# Altium schematic sheet [09] - USB HOST and SD CARD.SchDoc("[09] - USB HOST and SD CARD")
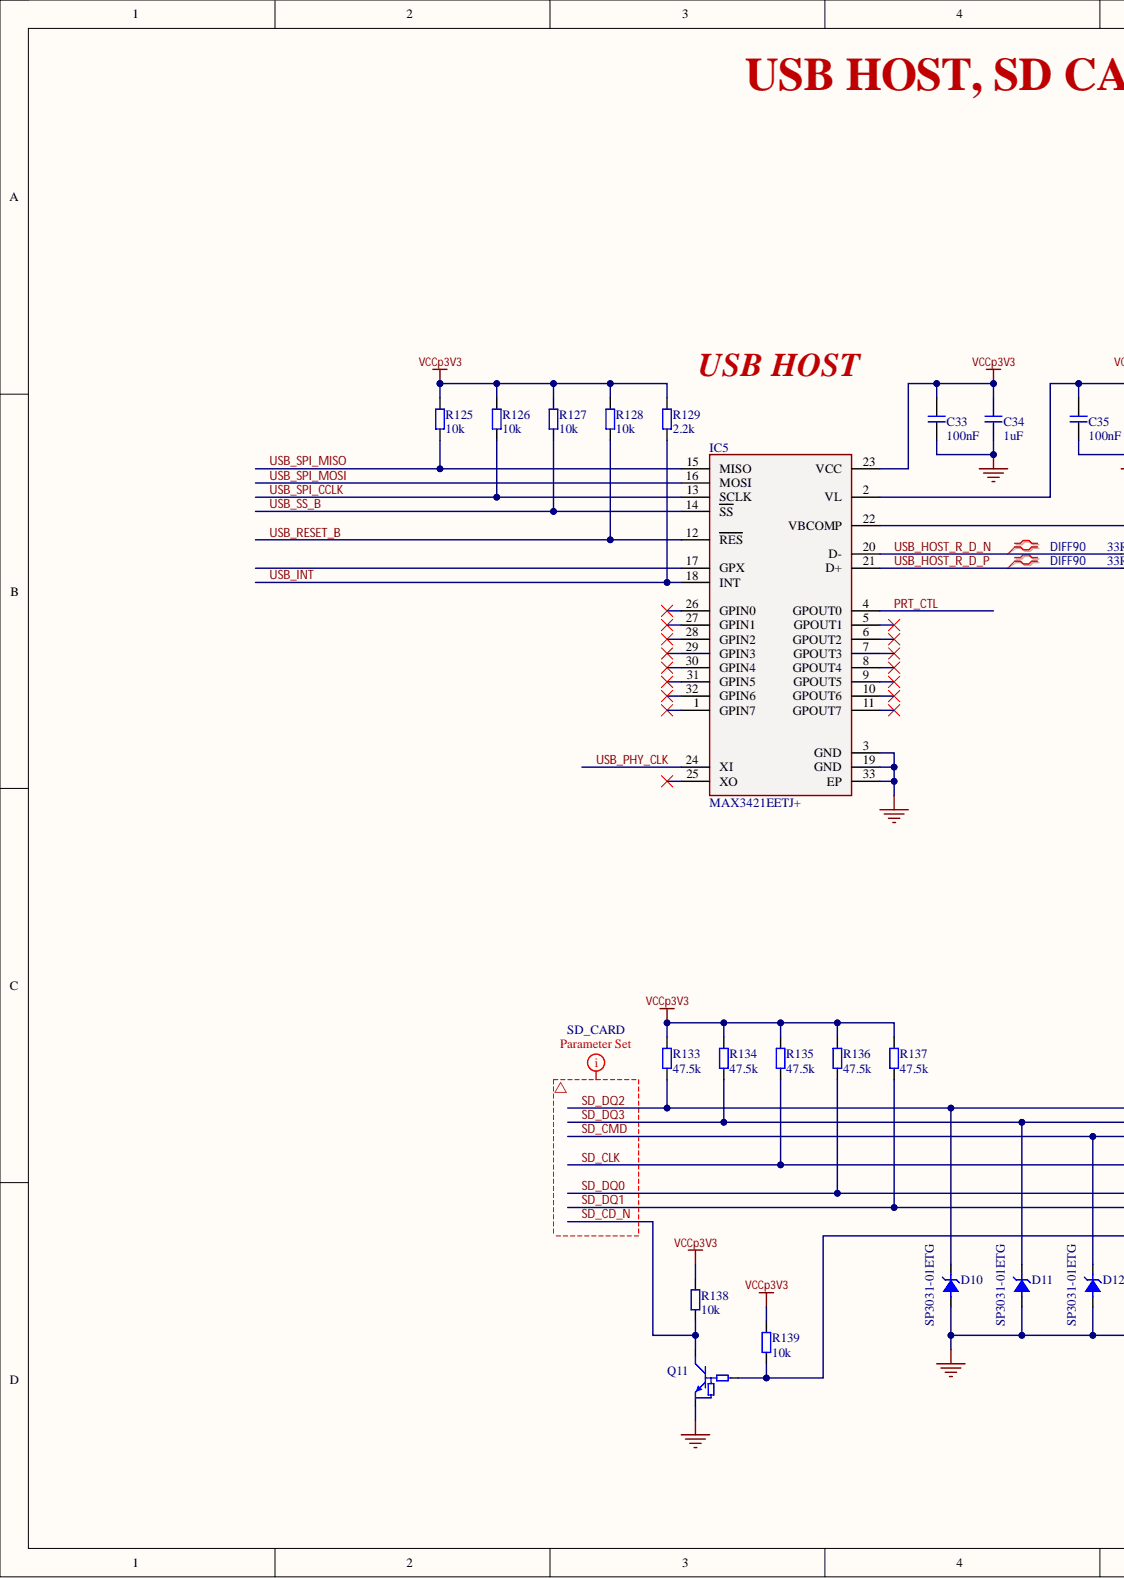
[diagram p0: [09] - USB HOST and SD CARD.SchDoc, left]
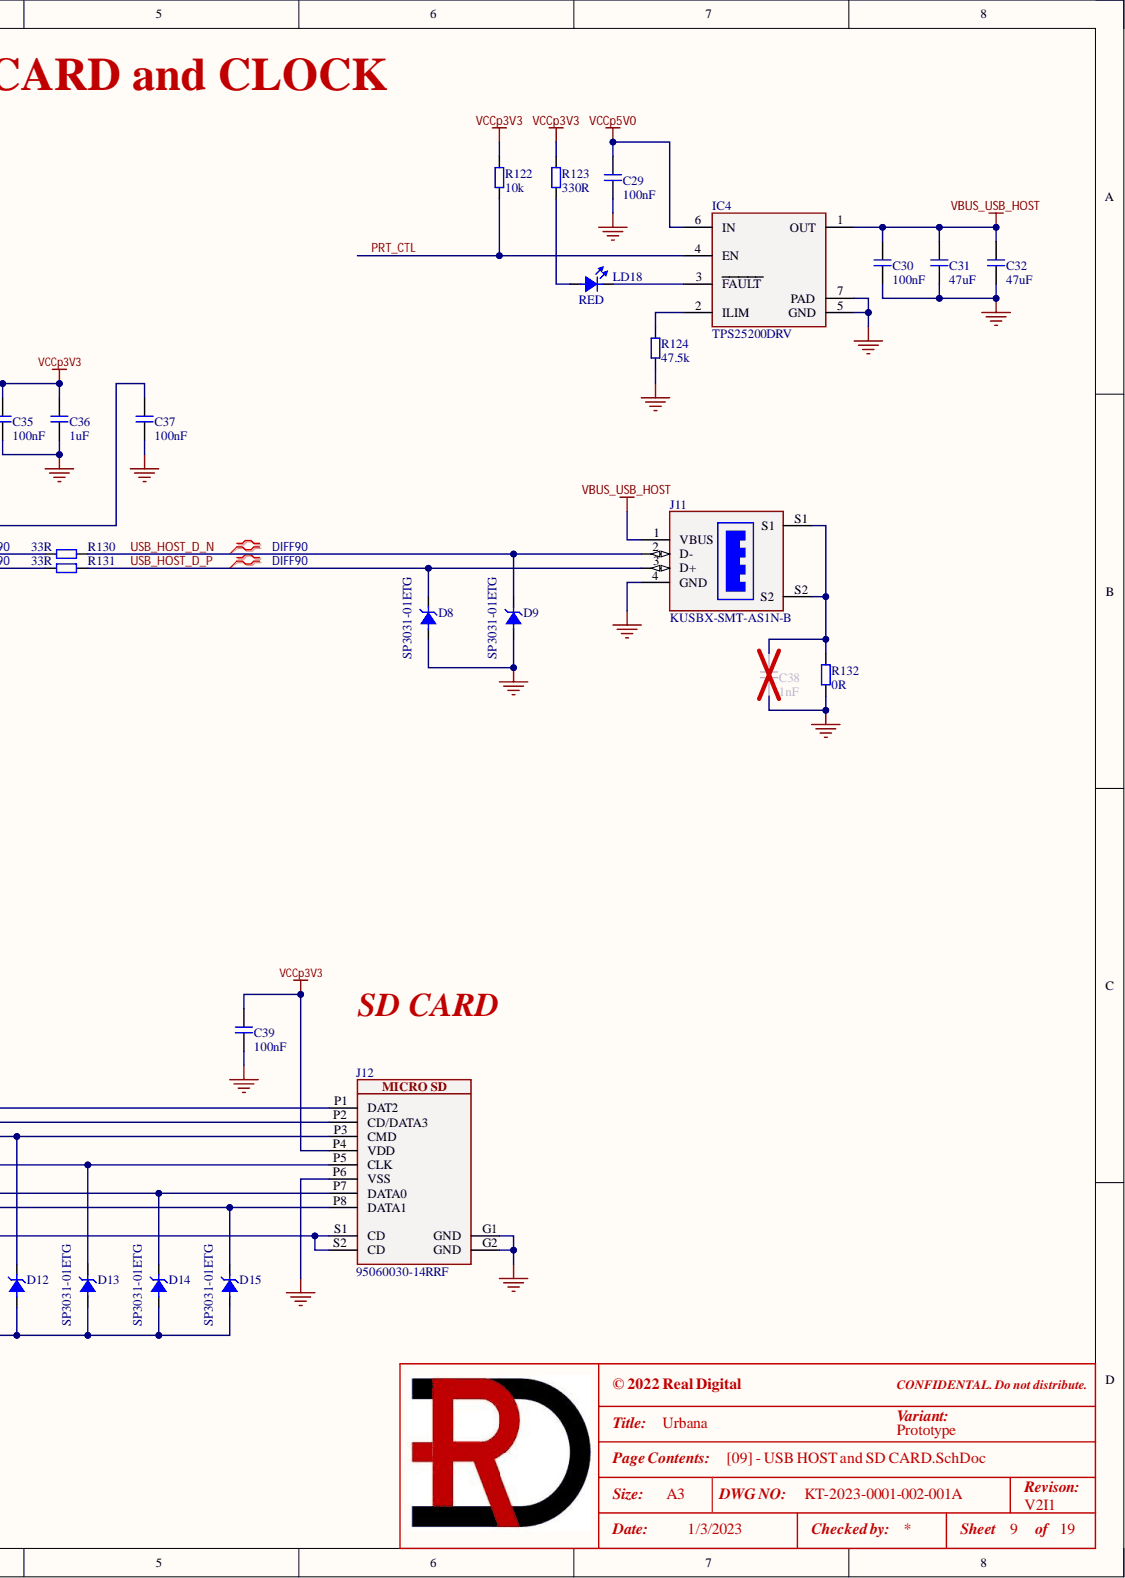
[diagram p1: [09] - USB HOST and SD CARD.SchDoc, right]

## Parts
C29  100nF  [C0402]
C30  100nF  [C0402]
C31  47uF  [C0805]
C32  47uF  [C0805]
C33  100nF  [C0402]
C34  1uF  [C0402]
C35  100nF  [C0402]
C36  1uF  [C0402]
C37  100nF  [C0402]
C38  1nF  [C0805]
C39  100nF  [C0402]
D8  SP3031-01ETG  [SOD882 - LITTLEFUSE]
D9  SP3031-01ETG  [SOD882 - LITTLEFUSE]
D10  SP3031-01ETG  [SOD882 - LITTLEFUSE]
D11  SP3031-01ETG  [SOD882 - LITTLEFUSE]
D12  SP3031-01ETG  [SOD882 - LITTLEFUSE]
D13  SP3031-01ETG  [SOD882 - LITTLEFUSE]
D14  SP3031-01ETG  [SOD882 - LITTLEFUSE]
D15  SP3031-01ETG  [SOD882 - LITTLEFUSE]
IC4  TPS25200DRV  [DRV0006A_WSON_6 - TI]
IC5  MAX3421EETJ+  [TQFN-32 - MAXIM]
J11  KUSBX-SMT-AS1N-B  [KUSBX-SMT-AS1N-B]
J12  95060030-14RRF  [95060030-14RRF]
LD18  RED  [LD0603_RED]
Q11  DTC043ZEBTL  [SC89-EMT3F]  (value not drawn)
R122  10k  [R0402]
R123  330R  [R0402]
R124  47.5k  [R0402]
R125  10k  [R0402]
R126  10k  [R0402]
R127  10k  [R0402]
R128  10k  [R0402]
R129  2.2k  [R0402]
R130  33R  [R0402]
R131  33R  [R0402]
R132  0R  [R0805]
R133  47.5k  [R0402]
R134  47.5k  [R0402]
R135  47.5k  [R0402]
R136  47.5k  [R0402]
R137  47.5k  [R0402]
R138  10k  [R0402]
R139  10k  [R0402]

## Nets
GND: C29.1 C30.1 C31.1 C32.1 C33.1 C34.1 C35.1 C36.1 C37.1 C38.2 C39.1 D8.A D9.A D10.A D11.A D12.A D13.A D14.A D15.A IC4.5(GND) IC4.7(PAD) IC5.3(GND) IC5.19(GND) IC5.33(EP) J11.4(GND) J12.G1(GND) J12.G2(GND) J12.P6(VSS) Q11.GND R124.1 R132.2
VBUS_USB_HOST: C30.2 C31.2 C32.2 IC4.1(OUT) J11.1(VBUS)
VCCp3V3: C33.2 C34.2 C35.2 C36.2 C39.2 IC5.2(VL) IC5.23(VCC) J12.P4(VDD) R122.2 R123.2 R125.2 R126.2 R127.2 R128.2 R129.2 R133.2 R134.2 R135.2 R136.2 R137.2 R138.2 R139.2
VCCp5V0: C29.2 IC4.6(IN)
PRT_CTL: IC4.4(EN) IC5.4(GPOUT0) R122.1
SD_CD_N: Q11.OUT R138.1
SD_CLK: D13.K J12.P5(CLK) R135.1
SD_CMD: D12.K J12.P3(CMD)
SD_DQ0: D14.K J12.P7(DATA0) R136.1
SD_DQ1: D15.K J12.P8(DATA1) R137.1
SD_DQ2: D10.K J12.P1(DAT2) R133.1
SD_DQ3: D11.K J12.P2(CD/DATA3) R134.1
USB_HOST_D_N: D9.K J11.2(D-) R130.2
USB_HOST_D_P: D8.K J11.3(D+) R131.2
USB_HOST_R_D_N: IC5.20(D-) R130.1
USB_HOST_R_D_P: IC5.21(D+) R131.1
USB_INT: IC5.18(INT) R129.1
USB_PHY_CLK: IC5.24(XI)
USB_RESET_B: IC5.12(RES) R128.1
USB_SPI_CCLK: IC5.13(SCLK) R126.1
USB_SPI_MISO: IC5.15(MISO) R125.1
USB_SPI_MOSI: IC5.16(MOSI)
USB_SS_B: IC5.14(SS) R127.1
NetC37_2: C37.2 IC5.22(VBCOMP)
NetC38_1: C38.1 J11.S1 J11.S2 R132.1
NetIC4_2: IC4.2(ILIM) R124.2
NetIC4_3: IC4.3(FAULT) LD18.K
NetJ12_S1: J12.S1(CD) J12.S2(CD) Q11.IN R139.1
NetLD18_A: LD18.A R123.1

Not connected: IC5 1(GPIN7) 5(GPOUT1) 6(GPOUT2) 7(GPOUT3) 8(GPOUT4) 9(GPOUT5) 10(GPOUT6) 11(GPOUT7) 17(GPX) 25(XO) 26(GPIN0) 27(GPIN1) 28(GPIN2) 29(GPIN3) 30(GPIN4) 31(GPIN5) 32(GPIN6)

## Library data of the parts
C29 C30 C33 C35 C37 C39 = GRM155R71E104KE14J: CAP CER 0.1uF 25V X7R 0402; Manufacturer 1: Murata Electronics; .Checked_PCB: AC; .Creator: RV; C P/N: RD-111-0006; LoadStatus: Load; Tolerance: 10%; Category: Capacitors; Component Kind: Standard; Library Name: KT-RealDigital.IntLib; Manufacturer 1 Part Number: GRM155R71E104KE14J; Temperature Coefficient: X7R; Voltage - Rated: 25V; .Checked_SCH: AC
C31 C32 = GRM21BR61A476ME15L: CAP CER 47uF 10V X5R 0805; Manufacturer 1: Murata Electronics; .Checked_PCB: RV; LoadStatus: Load; Tolerance: 20%; Category: Capacitors; Component Kind: Standard; Library Name: KT-RealDigital.IntLib; Manufacturer 1 Part Number: GRM21BR61A476ME15L; Temperature Coefficient: X5R; Voltage - Rated: 10V; .Checked_SCH: RV; .Creator: RV
C34 C36 = GRM155R70J105KA12J: CAP CER 1uF 6.3V X7R 0402; Manufacturer 1: Murata Electronics; .Checked_PCB: RV; LoadStatus: Load; Tolerance: 10%; Category: Capacitors; Component Kind: Standard; Library Name: KT-RealDigital.IntLib; Manufacturer 1 Part Number: GRM155R70J105KA12J; Temperature Coefficient: X7R; Voltage - Rated: 6.3V; .Checked_SCH: RV; .Creator: RV
C38 = GCJ21AR72E102KXJ1D: CAP CER 1nF 250V X7R 0805; Manufacturer 1: Murata Electronics; .Checked_PCB: RV; LoadStatus: Load; Tolerance: ±10%; Category: Capacitors; Component Kind: Standard; Library Name: KT-RealDigital.IntLib; Manufacturer 1 Part Number: GCJ21AR72E102KXJ1D; Temperature Coefficient: X7R; Voltage - Rated: 250V; .Checked_SCH: RV; .Creator: RV
D8-D15 = SP3031-01ETG: TVS DIODE 5V 7.5V SOD882; Manufacturer 1: Littelfuse Inc.; .Checked_PCB: RV; LoadStatus: Load; Category: TVS - Diodes; Component Kind: Standard; Library Name: KT-RealDigital.IntLib; Manufacturer 1 Part Number: SP3031-01ETG; .Checked_SCH: RV; .Creator: RV
IC4 = TPS25200DRV: IC PWR MGR EFUSE 6.5V 2.8A 6SON; Manufacturer 1: Texas Instruments; .Checked_PCB: RV; LoadStatus: Load; Category: IC; Component Kind: Standard; Library Name: KT-RealDigital.IntLib; Manufacturer 1 Part Number: TPS25200DRV; .Checked_SCH: RV; .Creator: RV
IC5 = MAX3421EETJ+: IC USB PERIPH/HOST CNTRL 32TQFN; Manufacturer 1: Maxim Integrated; .Checked_PCB: RV; LoadStatus: Load; Category: IC; Component Kind: Standard; Library Name: KT-RealDigital.IntLib; Manufacturer 1 Part Number: MAX3421EETJ+; .Checked_SCH: RV; .Creator: RV
J11 = KUSBX-SMT-AS1N-B: CONN RCPT USB2.0 TYPEA 4P SMD RA; Manufacturer 1: Kycon, Inc.; .Checked_PCB: RV; LoadStatus: Load; Category: Connectors; Component Kind: Standard; Library Name: KT-RealDigital.IntLib; Manufacturer 1 Part Number: KUSBX-SMT-AS1N-B; .Checked_SCH: RV; .Creator: RV
J12 = 95060030-14RRF: CONN MICRO SD CARD PUSH-PUSH R/A; Manufacturer 1: ANYTRONIC CORPORATION LIMITED; .Checked_PCB: RV; LoadStatus: Load; Category: Connectors; Component Kind: Standard; Library Name: KT-RealDigital.IntLib; Manufacturer 1 Part Number: 95060030-14RRF; .Checked_SCH: RV; .Creator: RV
LD18 = LED_RED: LED RED 0603; Manufacturer 1: EVERLIGHT ELECTRONICS CO.,LTD.; Manufacturer 1 Part Number: 19-21SURC/S530-XX/TR8; .Checked_PCB: AC; .Creator: RV; C P/N: 121-0001; LoadStatus: Load; Category: Led; Component Kind: Standard; Library Name: KT-RealDigital.IntLib; .Checked_SCH: AC
Q11 = DTC043ZEBTL: TRANS PREBIAS NPN 50V EMT3F; Manufacturer 1: Rohm Semiconductor; .Checked_PCB: RV; LoadStatus: Load; Category: BJTs; Component Kind: Standard; Library Name: KT-RealDigital.IntLib; Manufacturer 1 Part Number: DTC043ZEBTL; .Checked_SCH: RV; .Creator: RV
R122 R125-R128 R138 R139 = RC0402FR-0710KL: RES SMD 10K OHM 1% 1/16W 0402; Manufacturer 1: Yageo; .Checked_PCB: AC; .Creator: RV; LoadStatus: Load; Category: Resistors; Component Kind: Standard; Library Name: KT-RealDigital.IntLib; Manufacturer 1 Part Number: RC0402FR-0710KL; Tolerance: 1%; .Checked_SCH: AC
R123 = RC0402JR-07330RL: RES SMD 330 OHM 5% 1/16W 0402; Manufacturer 1: Yageo; .Checked_PCB: AC; .Creator: RV; C P/N: 101-0112; LoadStatus: Load; Category: Resistors; Component Kind: Standard; Library Name: KT-RealDigital.IntLib; Manufacturer 1 Part Number: RC0402JR-07330RL; Tolerance: 5%; .Checked_SCH: AC
R124 R133-R137 = RC0402FR-0747K5L: RES SMD 47.5K OHM 1% 1/16W 0402; Manufacturer 1: Yageo; .Checked_PCB: RV; LoadStatus: Load; Category: Resistors; Component Kind: Standard; Library Name: KT-RealDigital.IntLib; Manufacturer 1 Part Number: RC0402FR-0747K5L; Tolerance: 1%; .Checked_SCH: RV; .Creator: RV
R129 = RC0402JR-072K2L: RES SMD 2.2K OHM 5% 1/16W 0402; Manufacturer 1: Yageo; .Checked_PCB: AC; .Creator: RV; C P/N: RD-101-0005; LoadStatus: Load; Category: Resistors; Component Kind: Standard; Library Name: KT-RealDigital.IntLib; Manufacturer 1 Part Number: RC0402JR-072K2L; Tolerance: 5%; .Checked_SCH: AC
R130 R131 = RC0402FR-0733RL: RES SMD 33 OHM 1% 1/16W 0402; Manufacturer 1: Yageo; .Checked_PCB: RV; LoadStatus: Load; Category: Resistors; Component Kind: Standard; Library Name: KT-RealDigital.IntLib; Manufacturer 1 Part Number: RC0402FR-0733RL; Tolerance: 1%; .Checked_SCH: RV; .Creator: RV
R132 = RC0805JR-070RL: RES SMD 0 OHM JUMPER 1/8W 0805; Manufacturer 1: Yageo; .Checked_PCB: AC; .Creator: RV; LoadStatus: Load; Category: Resistors; Component Kind: Standard; Library Name: KT-RealDigital.IntLib; Manufacturer 1 Part Number: RC0805JR-070RL; Tolerance: Jumper; .Checked_SCH: AC
